# Altium schematic sheet DE-160724.SchDoc(DE-160724)
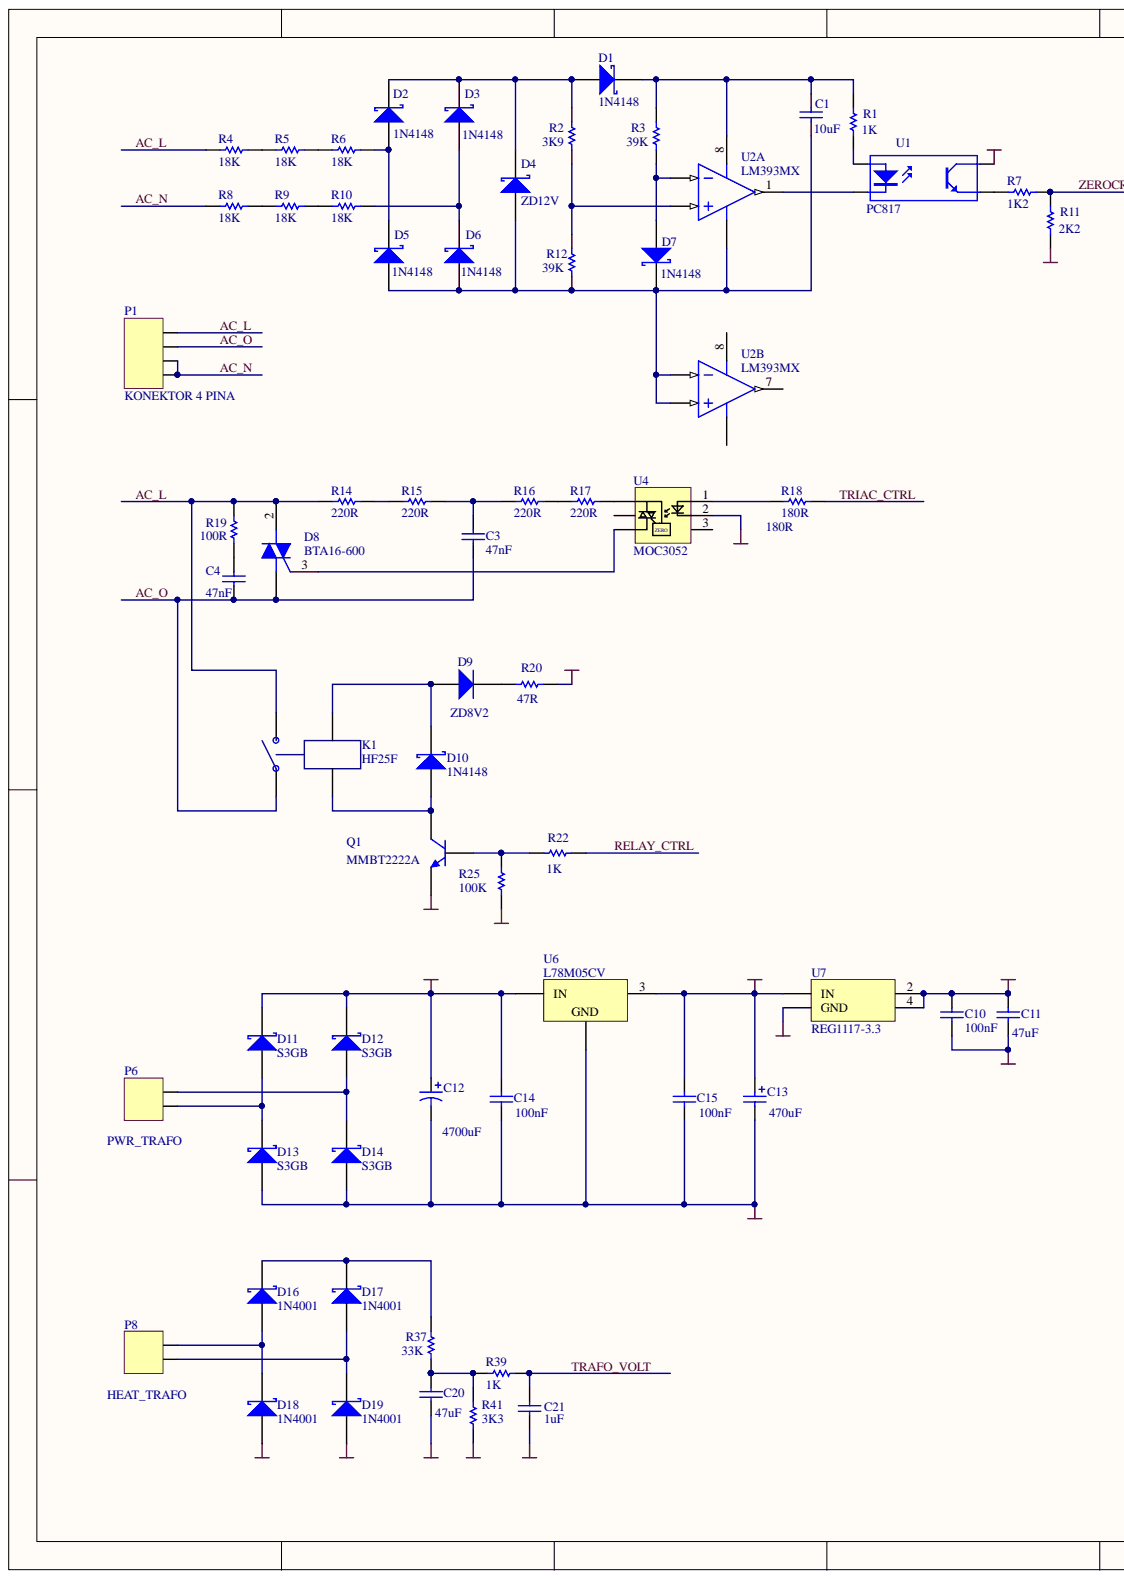
[diagram p0: DE-160724.SchDoc, left]
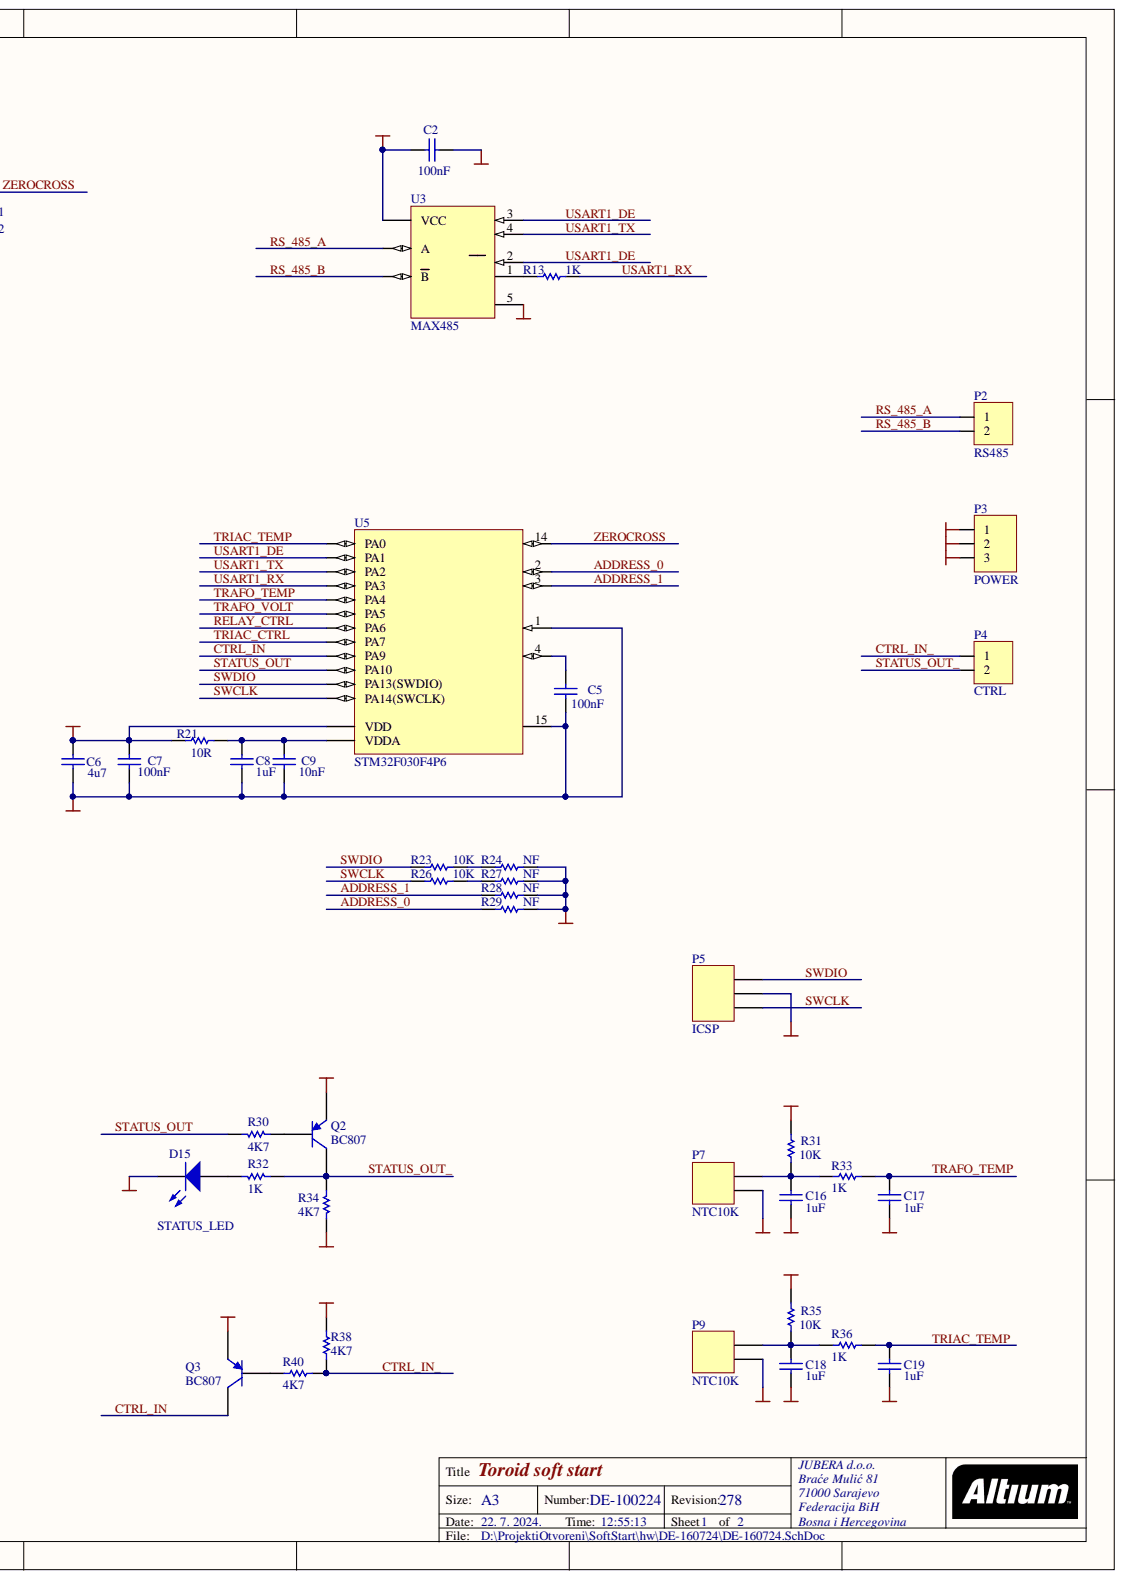
[diagram p1: DE-160724.SchDoc, right]

## Parts
C1  10uF  [0805]
C2  100nF  [0603]
C3  47nF  [X2 CAP]
C4  47nF  [X2 CAP]
C5  100nF  [0603]
C6  4u7  [0805]
C7  100nF  [0603]
C8  1uF  [0603]
C9  10nF  [0603]
C10  100nF  [0603]
C11  value 47uF  [1206]  (hidden comment: C1206)
C12  4700uF  [CAPPR5-13X25]
C13  470uF  [CAPPR5-10X16]
C14  100nF  [0603]
C15  100nF  [0603]
C16  1uF  [0603]
C17  1uF  [0603]
C18  1uF  [0603]
C19  1uF  [0603]
C20  value 47uF  [1206]  (hidden comment: C1206)
C21  1uF  [0603]
D1  1N4148  [SOD323]
D2  1N4148  [SOD323]
D3  1N4148  [SOD323]
D4  ZD12V  [SMB]
D5  1N4148  [SOD323]
D6  1N4148  [SOD323]
D7  1N4148  [SOD323]
D8  BTA16-600  [ONSC-TO-220-3-221A-07]
D9  ZD8V2  [DO-41]
D10  1N4148  [SOD323]
D11  S3GB  [SMB]
D12  S3GB  [SMB]
D13  S3GB  [SMB]
D14  S3GB  [SMB]
D15  STATUS_LED  [1.6X0.8X0.8]
D16  1N4001  [SMB]
D17  1N4001  [SMB]
D18  1N4001  [SMB]
D19  1N4001  [SMB]
K1  HF25F  [HF25F]
P1  KONEKTOR 4 PINA  [KONEKTOR 4 PINA]
P2  RS485  [TERMINAL BLOK 2]
P3  POWER  [TERMINAL BLOK 3]
P4  CTRL  [TERMINAL BLOK 2]
P5  ICSP  [HDR1X3]
P6  PWR_TRAFO  [KONEKTOR 2 PINA]
P7  NTC10K  [HDR1X2]
P8  HEAT_TRAFO  [KONEKTOR 2 PINA]
P9  NTC10K  [HDR1X2]
Q1  MMBT2222A  [SOT23_N]
Q2  BC807  [SOT23_N]
Q3  BC807  [SOT23_N]
R1  1K  [ERA-0603]
R2  3K9  [ERA-0603]
R3  39K  [ERA-0603]
R4  18K  [ERA-0805]
R5  18K  [ERA-0805]
R6  18K  [ERA-0805]
R7  1K2  [ERA-0603]
R8  18K  [ERA-0805]
R9  18K  [ERA-0805]
R10  18K  [ERA-0805]
R11  2K2  [ERA-0603]
R12  39K  [ERA-0603]
R13  1K  [ERA-0603]
R14  220R  [ERA-0805]
R15  220R  [ERA-0805]
R16  220R  [ERA-0805]
R17  220R  [ERA-0805]
R18  180R  [ERA-0603]
R19  100R  [1W100R]
R20  47R  [AXIAL-0.3]
R21  10R  [ERA-0603]
R22  1K  [ERA-0603]
R23  10K  [ERA-0603]
R24  NF  [ERA-0603]
R25  100K  [ERA-0603]
R26  10K  [ERA-0603]
R27  NF  [ERA-0603]
R28  NF  [ERA-0603]
R29  NF  [ERA-0603]
R30  4K7  [ERA-0603]
R31  10K  [ERA-0603]
R32  1K  [ERA-0603]
R33  1K  [ERA-0603]
R34  4K7  [ERA-0603]
R35  10K  [ERA-0603]
R36  1K  [ERA-0603]
R37  33K  [ERA-0603]
R38  4K7  [ERA-0603]
R39  1K  [ERA-0603]
R40  4K7  [ERA-0603]
R41  3K3  [ERA-0603]
U1  PC817  [DIP-4]
U2  LM393MX  [M08A_N]  (parts A,B)
U3  MAX485  [D008_L]
U4  MOC3052  [DIP6]
U5  STM32F030F4P6  [STM-TSSOP20_N]
U6  L78M05CV  [TO220]
U7  REG1117-3.3  [ZZ311]

## Nets
+3V3: C6.1 C7.1 C10.2 C11.2 Q2.2 Q3.2 R21.2 R31.2 R35.2 R38.1 U5.16(VDD) U7.2 U7.4
+5V: C2.1 C13.1 C15.2 P3.1 U1.4 U3.8(VCC) U6.3 U7.3(IN)
+24V: C12.1 C14.2 D11.2 D12.2 P3.3 R20.2 U6.1
GND: C2.2 C5.2 C6.2 C7.2 C8.2 C9.2 C10.1 C11.1 C12.2 C13.2 C14.1 C15.1 C16.2 C17.2 C18.2 C19.2 C20.1 C21.2 D13.1 D14.1 D15.2 D18.1 D19.1 P3.2 P5.2 P7.1 P9.1 Q1.2 R11.1 R24.1 R25.1 R27.1 R28.1 R29.1 R34.2 R41.1 U3.5 U4.2 U5.1 U5.15 U6.2 U7.1(GND)
AC_L: D8.2 K1.4 P1.1 R4.1 R14.1 R19.2
AC_N: P1.3 P1.4 R8.1
AC_O: C3.1 C4.1 D8.1 K1.3 P1.2
ADDRESS_0: R29.2 U5.2
ADDRESS_1: R28.2 U5.3
CTRL_IN: Q3.3 U5.17(PA9)
CTRL_IN_: P4.1 R38.2 R40.2
RELAY_CTRL: R22.1 U5.12(PA6)
RS_485_A: P2.1 U3.6(A)
RS_485_B: P2.2 U3.7(B)
STATUS_OUT: R30.2 U5.18(PA10)
STATUS_OUT_: P4.2 Q2.3 R32.1 R34.1
SWCLK: P5.1 R26.2 U5.20(PA14(SWCLK))
SWDIO: P5.3 R23.2 U5.19(PA13(SWDIO))
TRAFO_TEMP: C17.1 R33.1 U5.10(PA4)
TRAFO_VOLT: C21.1 R39.1 U5.11(PA5)
TRIAC_CTRL: R18.1 U5.13(PA7)
TRIAC_TEMP: C19.1 R36.1 U5.6(PA0)
USART1_DE: U3.2 U3.3 U5.7(PA1)
USART1_RX: R13.1 U5.9(PA3)
USART1_TX: U3.4 U5.8(PA2)
ZEROCROSS: R7.1 R11.2 U5.14
NetC1_1: C1.1 D4.1 D5.2 D6.2 D7.1 R12.1 U2.4 U2.5 U2.6
NetC1_2: C1.2 D1.1 R1.2 R3.2 U2.8
NetC3_2: C3.2 R15.2 R16.1
NetC4_2: C4.2 R19.1
NetC5_1: C5.1 U5.4
NetC8_1: C8.1 C9.1 R21.1 U5.5(VDDA)
NetC16_1: C16.1 P7.2 R31.1 R33.2
NetC18_1: C18.1 P9.2 R35.1 R36.2
NetC20_2: C20.2 R37.1 R39.2 R41.2
NetD1_2: D1.2 D2.1 D3.1 D4.2 R2.2
NetD2_2: D2.2 D5.1 R6.2
NetD3_2: D3.2 D6.1 R10.2
NetD7_2: D7.2 R3.1 U2.2
NetD8_3: D8.3 U4.4
NetD9_1: D9.1 D10.1 K1.2
NetD9_2: D9.2 R20.1
NetD10_2: D10.2 K1.1 Q1.3
NetD11_1: D11.1 D13.2 P6.1
NetD12_1: D12.1 D14.2 P6.2
NetD15_1: D15.1 R32.2
NetD16_1: D16.1 D18.2 P8.2
NetD16_2: D16.2 D17.2 R37.2
NetD17_1: D17.1 D19.2 P8.1
NetQ1_1: Q1.1 R22.2 R25.2
NetQ2_1: Q2.1 R30.1
NetQ3_1: Q3.1 R40.1
NetR1_1: R1.1 U1.1
NetR2_1: R2.1 R12.2 U2.3
NetR4_2: R4.2 R5.1
NetR5_2: R5.2 R6.1
NetR7_2: R7.2 U1.3
NetR8_2: R8.2 R9.1
NetR9_2: R9.2 R10.1
NetR13_2: R13.2 U3.1
NetR14_2: R14.2 R15.1
NetR16_2: R16.2 R17.1
NetR17_2: R17.2 U4.6
NetR18_2: R18.2 U4.1
NetR23_1: R23.1 R24.2
NetR26_1: R26.1 R27.2
NetU1_2: U1.2 U2.1

Not connected: U2 7; U4 3 5

## Library data of the parts
C1 C6 = C0805: Ceramic Chip Capacitor - Standard; Note: Footprint corresponds to dimensions for bulk cassette packaging. This case size has tightened tolerances.; Simulation: CAP; Signal Integrity: C0805; Published: 17-Jul-2002; PackageReference: 0805; PackageDocument: Mar-2001; LatestRevisionNote: Silkscreen size reduced.; LatestRevisionDate: 19-Mar-2004; DatasheetDocument: Mar-2001; ComponentLink2URL: http://www.kemet.com/kemet/web/homepage/kechome.nsf/vapubfilesname/F3102TA.pdf/$file/F3102TA.pdf; ComponentLink2Description: Datasheet; ComponentLink1URL: http://www.kemet.com/; ComponentLink1Description: Manufacturer Link; Component Kind: Standard; Code_JEITA: 0805; Code_IPC: 2012[0805]; Publisher: Altium Limited
  C1: Value: 10uF; Library Name: DE-100224.SchLib
  C6: Value: 4u7; Library Name: KEMET Chip Capacitor.IntLib
C2 C5 C7-C10 C14-C19 C21 = C0603: Ceramic Chip Capacitor - Standard; Note: Footprint corresponds to dimensions for bulk cassette packaging. This case size has tightened tolerances.; Simulation: CAP; Signal Integrity: C0603; Published: 17-Jul-2002; PackageReference: 0603; PackageDocument: Mar-2001; LatestRevisionNote: Silkscreen size reduced.; LatestRevisionDate: 19-Mar-2004; DatasheetDocument: Mar-2001; ComponentLink2URL: http://www.kemet.com/kemet/web/homepage/kechome.nsf/vapubfilesname/F3102TA.pdf/$file/F3102TA.pdf; ComponentLink2Description: Datasheet; ComponentLink1URL: http://www.kemet.com/; ComponentLink1Description: Manufacturer Link; Component Kind: Standard; Code_JEITA: 0603; Code_IPC: 1608 [0603]; Publisher: Altium Limited
  C2 C5 C7: Value: 100nF; Library Name: KEMET Chip Capacitor.IntLib
  C8 C16-C19 C21: Value: 1uF; Library Name: KEMET Chip Capacitor.IntLib
  C9: Value: 10nF; Library Name: KEMET Chip Capacitor.IntLib
  C10: Library Name: DE-251215.SchLib
  C14 C15: Value: 100nF; Library Name: DE-100224.SchLib
C3 C4 = CAP X2: X2 275VAC capaitor; Library Name: DE-100224.SchLib; Component Kind: Standard
C11 C20 = C1206: Ceramic Chip Capacitor - Standard; Simulation: CAP; Signal Integrity: C1206; Published: 17-Jul-2002; PackageReference: 1206; PackageDocument: Mar-2001; Library Name: DE-160724.SchLib; LatestRevisionNote: Silkscreen size reduced.; LatestRevisionDate: 19-Mar-2004; DatasheetDocument: Mar-2001; ComponentLink2URL: http://www.kemet.com/kemet/web/homepage/kechome.nsf/vapubfilesname/F3102TA.pdf/$file/F3102TA.pdf; ComponentLink2Description: Datasheet; ComponentLink1URL: http://www.kemet.com/; ComponentLink1Description: Manufacturer Link; Component Kind: Standard; Code_JEITA: 1206; Code_IPC: 3216 [1206]; Publisher: Altium Limited
C12 = EKA00GG447C00: Aluminum Electrolytic Capacitor, Radial Style with Long Leads: 4700uF, 10V; Note: Refer to Manufacturer's Data Sheets for other lead formations; DrillSize: Nominal, Rounded To The Nearest 0.1mm; Value: 4700uF; Simulation: CAP; Signal Integrity: EKA00GG447C00; Published: 19-Mar-2004; PackageReference: CAPPR5-13X25; DatasheetDocument: 20-Feb-2001; ComponentLink2URL: http://www.vishay.com/docs/25014/eka.pdf; ComponentLink2Description: Datasheet; ComponentLink1URL: http://www.vishay.com/; ComponentLink1Description: Manufacturer Link; Component Kind: Standard; Publisher: Altium Limited; Library Name: Vishay Roederstein Electrolytic Radial-Lead Capacitor.IntLib
C13 = EKA00DD347F00: Aluminum Electrolytic Capacitor, Radial Style with Long Leads: 470uF, 35V; Note: Refer to Manufacturer's Data Sheets for other lead formations; DrillSize: Nominal, Rounded To The Nearest 0.1mm; Value: 470uF; Simulation: CAP; Signal Integrity: EKA00DD347F00; Published: 19-Mar-2004; PackageReference: CAPPR5-10X16; Library Name: DE-100224.SchLib; DatasheetDocument: 20-Feb-2001; ComponentLink2URL: http://www.vishay.com/docs/25014/eka.pdf; ComponentLink2Description: Datasheet; ComponentLink1URL: http://www.vishay.com/; ComponentLink1Description: Manufacturer Link; Component Kind: Standard; Publisher: Altium Limited
D1-D3 D5-D7 D10 = 1PS76SB10: Schottky Barrier Diode; Simulation: D1PS76SBD10; Signal Integrity: 1PS76SB10; Published: 17-Sep-2003; PackageVersion: May-1999; PackageReference: SOD323; PackageDescription: SOD-323/SC-76; 2 Leads; Body 1.7 x 1.3 mm, (LxW); Library Name: DE-100224.SchLib; LatestRevisionNote: Silkscreen size reduced.; LatestRevisionDate: 19-Mar-2004; DatasheetVersion: 14-Oct-1996; ComponentLink2URL: http://www.semiconductors.philips.com/acrobat/datasheets/1PS76SB10_2.pdf; ComponentLink2Description: Datasheet; ComponentLink1URL: http://www.semiconductors.philips.com/; ComponentLink1Description: Manufacturer Link; Component Kind: Standard; Publisher: Altium Limited
D4 = 10BQ100: Schottky Rectifier; Simulation: 10BQ100; Signal Integrity: 10BQ100; Published: 8-Jun-2000; PackageReference: SMB; PackageDescription: DO-214-AA/SMB; 2 C-Bend Leads; Body 5.3 x 3.6 mm, inc. leads (LxW); Library Name: DE-100224.SchLib; LatestRevisionNote: Re-released for DXP Platform.; LatestRevisionDate: 17-Jul-2002; DatasheetVersion: PD-2.437A; ComponentLink2URL: http://www.irf.com/product-info/datasheets/data/10bq100.pdf; ComponentLink2Description: Datasheet; ComponentLink1URL: http://www.irf.com/; ComponentLink1Description: Manufacturer Link; Component Kind: Standard; Publisher: Altium Limited
D8 = BTA08-600BW3G: Triacs, Silicon Bidirectional Thyristor, 3-Pin TO-220, Pb-Free, Tube; Manufacturer: On Semiconductor; ITSM Max (A): 90; IT(RMS) Max (A): 8; IGT Max (mA): 50; Supplier Part Number 3: 863-BTA08-600BW3G; Supplier Part Number 2: 13P2072; Supplier Part Number 1: BTA08-600BW3G-ND; Supplier 3: Mouser; Supplier 2: Newark; Supplier 1: Digi-Key; RoHS: Pb-Free; PartNumber: BTA08-600BW3G; Packing: Tube; PackageVersion: Rev. O, 07/2008; PackageReference: TO-220-3-221A-07; PackageDescription: 3-Pin Flange Mount, Vertical Straight Lead, Body 9.97 x 4.445 mm, Pitch 2.54 mm; Mounting Technology: Through Hole; Library Name: DE-100224.SchLib; DatasheetVersion: Rev. 1; ComponentLink3URL: http://www.onsemi.com/pub_link/Collateral/221A-07.PDF; ComponentLink3Description: Package Specification; ComponentLink2URL: http://www.onsemi.com/pub_link/Collateral/BTA08-600BW3-D.PDF; ComponentLink2Description: Datasheet; ComponentLink1URL: http://www.onsemi.com/; ComponentLink1Description: Manufacturer URL; Component Kind: Standard
D9 = 1N4003: 1.0 Ampere General Purpose Rectifier; Simulation: 1N4003; Signal Integrity: 1N4003; Published: 8-Jun-2000; PackageReference: DO-41; PackageDocument: Aug-1999; Library Name: DE-100224.SchLib; LatestRevisionNote: Re-released for DXP Platform.; LatestRevisionDate: 17-Jul-2002; DatasheetDocument: 1998; ComponentLink2URL: http://www.fairchildsemi.com/ds/1N%2F1N4001.pdf; ComponentLink2Description: Pinout Specification; ComponentLink1URL: http://www.fairchildsemi.com/; ComponentLink1Description: Manufacturer Link; Component Kind: Standard; Code_JEDEC: DO-204-AL; Publisher: Altium Limited
D11-D14 D16-D19 = D Schottky: Schottky Diode; Simulation: SKYDIODE; Signal Integrity: D Schottky; Published: 8-Jun-2000; PackageReference: SMB; PackageDescription: DO-214-AA/SMB; 2 C-Bend Leads; Body 5.3 x 3.6 mm, inc. leads (LxW); Library Name: Miscellaneous Devices.IntLib; LatestRevisionNote: Re-released for DXP Platform.; LatestRevisionDate: 17-Jul-2002; Component Kind: Standard; Code_JEDEC: DO-214-AA; Publisher: Altium Limited
D15 = HSMB-C190: Blue Surface Mount Chip LED, Blue; PCB_Layout: Complies with manufacturer's recommendation.; LuminousIntensity: 1.6mcd (Minimum).; Simulation: Agl_HSMBC110; Signal Integrity: LED; Published: 11-Dec-2002; PackageVersion: Mar-2002; PackageReference: 1.6X0.8X0.8; PackageDescription: SM LED; 2 Flat Leads; Library Name: DE-160724.SchLib; LED_Colour: Blue; DatasheetVersion: 26-Feb-2002; ComponentLink2URL: http://cp.literature.agilent.com/litweb/pdf/5988-5211EN.pdf; ComponentLink2Description: Datasheet; ComponentLink1URL: http://www.avagotech.com/; ComponentLink1Description: Manufacturer Link; Component Kind: Standard; Publisher: Altium Limited
K1 = HF25F: PCB relay 250VAC/20A 30VDC/16A; Library Name: DE-100224.SchLib; Component Kind: Standard
P1 = KONEKTOR 4 PINA: Razdvojivi konektor 4 pina; Library Name: DE-100224.SchLib; Component Kind: Standard
P2 P4 = TERMINAL BLOK 2: Terminal blok konektor 3,5 mm; Library Name: DE-160724.SchLib; Component Kind: Standard
P3 = TERMINAL BLOK 3: 3 pinski terminal blok konektor; Library Name: DE-100224.SchLib; Component Kind: Standard
P5 = Header 3: Header, 3-Pin; Signal Integrity: Connector; Library Name: Miscellaneous Connectors.IntLib; LatestRevisionNote: Re-released for DXP Platform.; LatestRevisionDate: 17-Jul-2002; Component Kind: Standard; Publisher: Altium Limited
P6 P8 = KONEKTOR 2 PINA: Razdvojivi konetor 2 pina; Library Name: DE-100224.SchLib; Component Kind: Standard
P7 P9 = Header 2: Header, 2-Pin; Signal Integrity: Connector; Library Name: Miscellaneous Connectors.IntLib; LatestRevisionNote: Re-released for DXP Platform.; LatestRevisionDate: 17-Jul-2002; Component Kind: Standard; Publisher: Altium Limited
Q1 = BC817: NPN General-purpose Transistor; Simulation: QBC817; Signal Integrity: BC817; Published: 17-Sep-2003; PackageVersion: May-1999; PackageReference: SOT23; PackageDescription: 3-Pin SOT-23 Package 0.95 mm Pitch, 2.3 mm Lead Span; Library Name: DE-100224.SchLib; LatestRevisionNote: IPC-7351 Footprint Added.; LatestRevisionDate: 12-Dec-2006; DatasheetVersion: Jun-1999; ComponentLink2URL: http://www.semiconductors.philips.com/acrobat/datasheets/BC817_BC817W_BC337_5.pdf; ComponentLink2Description: Datasheet; ComponentLink1URL: http://www.semiconductors.philips.com/; ComponentLink1Description: Manufacturer Link; Component Kind: Standard; Publisher: Altium Limited
Q2 Q3 = BC807: PNP General-purpose Transistor; Simulation: QBC807; Signal Integrity: BC807; Published: 17-Sep-2003; PackageVersion: May-1999; PackageReference: SOT23; PackageDescription: 3-Pin SOT-23 Package 0.95 mm Pitch, 2.3 mm Lead Span; Library Name: DE-160724.SchLib; LatestRevisionNote: IPC-7351 Footprint Added.; LatestRevisionDate: 12-Dec-2006; DatasheetVersion: 8-Apr-1999; ComponentLink2URL: http://www.semiconductors.philips.com/acrobat/datasheets/BC807_BC807W_BC327_5.pdf; ComponentLink2Description: Datasheet; ComponentLink1URL: http://www.semiconductors.philips.com/; ComponentLink1Description: Manufacturer Link; Component Kind: Standard; Publisher: Altium Limited
R1-R3 R7 R11 R12 R18 R22 R25 R31 R33 R35-R37 R39 R41 = ERAV: Metal Film Thermosensitive Chip Resistor, 0.56 Ohm to 10k Ohm Range, 5% Tolerance, 0603 Size, 0.063 W; Note: If flow soldering, adjust pad width of PCB Footprint to 0.7 - 0.8 times the width of the resistor.; Simulation: RESISTOR; Signal Integrity: Res; Published: 17-Dec-2003; PackageReference: ERA-0603; PackageDocument: Mar-2003; Library Name: DE-100224.SchLib; LatestRevisionNote: Silkscreen size reduced.; LatestRevisionDate: 19-Mar-2004; ComponentLink2URL: http://www.panasonic.com/industrial/components/pdf/AOF0000CE1.pdf; ComponentLink2Description: Datasheet; ComponentLink1URL: http://www.panasonic.com/industrial/components/resistive_products.htm; ComponentLink1Description: Manufacturer Link; Component Kind: Standard; Code_IPC: 1608 [0603]; Publisher: Altium Limited
  R18: Value: 180R
R4-R6 R8-R10 R14-R17 = ERAS: Metal Film Thermosensitive Chip Resistor, 0.56 Ohm to 10k Ohm Range, 5% Tolerance, 0805 Size, 0.1 W; Note: If flow soldering, adjust pad width of PCB Footprint to 0.7 - 0.8 times the width of the resistor.; Simulation: RESISTOR; Signal Integrity: Res; Published: 17-Dec-2003; PackageReference: ERA-0805; PackageDocument: Mar-2003; Library Name: DE-100224.SchLib; LatestRevisionNote: Silkscreen size reduced.; LatestRevisionDate: 19-Mar-2004; ComponentLink2URL: http://www.panasonic.com/industrial/components/pdf/AOF0000CE1.pdf; ComponentLink2Description: Datasheet; ComponentLink1URL: http://www.panasonic.com/industrial/components/resistive_products.htm; ComponentLink1Description: Manufacturer Link; Component Kind: Standard; Code_IPC: 2012 [0805]; Publisher: Altium Limited
R13 R21 R23 R24 R26-R30 R32 R34 R38 R40 = ERA6Y: Rectangular Thick Film Chip Resistor, 10 Ohm to 1M Ohm Range, 0.1% and 0.5% Tolerance, 0805 Size, 0.1 W; Note: If flow soldering, adjust pad width of PCB Footprint to 0.7 - 0.8 times the width of the resistor.; Simulation: RESISTOR; Signal Integrity: Res; Published: 17-Dec-2003; PackageReference: ERA-0805; PackageDocument: Mar-2003; Library Name: DE-280817.SchLib; LatestRevisionNote: Silkscreen size reduced.; LatestRevisionDate: 19-Mar-2004; ComponentLink2URL: http://www.panasonic.com/industrial/components/pdf/AOA0000CE8.pdf; ComponentLink2Description: Datasheet; ComponentLink1URL: http://www.panasonic.com/industrial/components/resistive_products.htm; ComponentLink1Description: Manufacturer Link; Component Kind: Standard; Code_IPC: 2012 [0805]; Publisher: Altium Limited
R19 = 1W100R: Carbon F 1W resistor; Published: 17-Dec-2003; PackageReference: RD6.35x2.3; Library Name: DE-100224.SchLib; ComponentLink2URL: http://www.panasonic.com/industrial/components/pdf/AOA0000CE12.pdf; ComponentLink2Description: Datasheet; ComponentLink1URL: http://www.panasonic.com/industrial/components/resistive_products.htm; ComponentLink1Description: Manufacturer Link; Component Kind: Standard; Publisher: Altium Limited
R20 = Res1: Resistor; Value: 47R; Simulation: RESISTOR; Signal Integrity: Res; Published: 8-Jun-2000; PackageReference: AXIAL-0.3; PackageDescription: Axial Device, Thru-Hole; 2 Leads; 0.3 in Pin Spacing; Library Name: Miscellaneous Devices.IntLib; LatestRevisionNote: Re-released for DXP Platform.; LatestRevisionDate: 17-Jul-2002; Component Kind: Standard; Publisher: Altium Limited
U1 = PC817: 4-Pin Phototransistor Optocoupler; Published: 24-Mar-1999; PackageReference: DIP-4; Library Name: DE-100224.SchLib; LatestRevisionNote: Sch pins 3 & 4 Swapped.; LatestRevisionDate: 04-Mar-2005; DatasheetVersion: Nov-2003; Component Kind: Standard; Publisher: Altium Limited
U2 = LM393MX: Low Power Low Offset Voltage Dual Comparator, 8-pin Narrow SOIC; Manufacturer: National Semiconductor; PowerWise: N; PackageTopMarking: NSZXTT*LM*393M; High-Reliability: Y; RoHS: N; PinCount: 8; PartNumber: LM393MX; PackageVersion: revM, May-2009; PackageReference: M08A; PackageDescription: SOIC Narrow, 4.9 x 3.9 x 1.45mm, 8 Lead, 1.27mm Pitch; Library Name: National Semiconductor Comparator.IntLib; DatasheetVersion: Aug-2002; CompType: Comparator; ComponentLink4URL: http://www.national.com/packaging/folders/m08a.html; ComponentLink4Description: M08A Package Page; ComponentLink3URL: http://www.national.com/pf/LM/LM393.html; ComponentLink3Description: LM393 Web Page; ComponentLink2URL: http://www.national.com/ds/LM/LM393.pdf; ComponentLink2Description: LM393 Datasheet; ComponentLink1URL: http://www.national.com/; ComponentLink1Description: Manufacturer URL; Component Kind: Standard; Code_JEDEC: MS-012-AA; Code_IPC: SOIC127P600-8
U3 = SN75176BD: Differential Bus Transceiver; Note: Receiver Hysteresis of 50mV Typical; Published: 15-May-2002; PCB3D: D008; PackageVersion: Jan-1998; PackageReference: D008; PackageDescription: 8-Pin Small Outline Integrated Circuit 1.27 mm Pitch; Library Name: DE-280817.SchLib; LatestRevisionNote: IPC-7351 Footprint Added.; LatestRevisionDate: 13-Apr-2006; DatasheetVersion: Sep-1989; ComponentLink2URL: http://www-s.ti.com/sc/ds/sn75176b.pdf; ComponentLink2Description: Datasheet; ComponentLink1URL: http://www.ti.com/; ComponentLink1Description: Manufacturer Link; Component Kind: Standard; Code_IPC: SOIC127P600-8; Publisher: Altium Limited
U4 = MOC3081: Zero-Cross Optoisolator Triac Driver; Library Name: DE-100224.SchLib; Component Kind: Standard
U5 = STM32F030F4P6: ARM Cortex-M0 32-bit MCU, 16 KB Flash, 4 KB Internal RAM, 15 I/Os, 20-pin TSSOP, -40 to 85 degC, Tube; Supply Voltage(Vcc) min (V): 2.4; Operating Frequency(F) (Processor speed) (MHz): 48; Internal RAM Size (kB): 4; I/Os (High Current): 15; FLASH Size (Prog) (kB): 16; Supplier Part Number 3: 511-STM32F030F4P6; Supplier Part Number 2: 06X3648; Supplier Part Number 1: 497-14044-5-ND; Supplier 3: Mouser; Supplier 2: Newark; Supplier 1: Digi-Key; PartNumber: STM32F030F4P6; Packing: Tube; PackageVersion: Rev. 1, 11/2012; PackageReference: TSSOP20; PackageDescription: 20-Pin Thin Shrink Small Outline Package, 6.5 x 4.4 mm Body, 0.65 mm Pitch; Mounting Technology: Surface Mount; Library Name: STMicroelectronics STM32 F0.IntLib; DatasheetVersion: Rev. 1; Core: ARM Cortex-M0; ComponentLink3URL: http://www.st.com/st-web-ui/static/active/en/resource/technical/document/datasheet/DM00065136.pdf; ComponentLink3Description: Package Specification; ComponentLink2URL: http://www.st.com/st-web-ui/static/active/en/resource/technical/document/datasheet/DM00088500.pdf; ComponentLink2Description: Datasheet; ComponentLink1URL: http://www.st.com/web/en/home.html; ComponentLink1Description: Manufacturer URL; Component Kind: Standard
U6 = L78M05CV: Positive Voltage Regulator, 5V, 3-Pin TO-220; PartNumber: L78M05CV; PackageVersion: ver3.0; PackageReference: TO220; PackageDescription: TO, Thru-Hole, Vertical, Heatsink Mounted; 3 In-Line Leads; Pitch 2.54 mm; Library Name: STMicroelectronics Power Management Linear Regulator.IntLib; DatasheetVersion: Nov-2010; ComponentLink3URL: http://www.st.com/internet/com/TECHNICAL_RESOURCES/TECHNICAL_LITERATURE/PACKAGE_INFORMATION/CD00004649.pdf; ComponentLink3Description: Package Specification; ComponentLink2URL: http://www.st.com/internet/com/TECHNICAL_RESOURCES/TECHNICAL_LITERATURE/DATASHEET/CD00000447.pdf; ComponentLink2Description: Datasheet; ComponentLink1URL: http://www.st.com; ComponentLink1Description: Manufacturer URL; Component Kind: Standard
U7 = REG1117-3.3: 800mA and 1A Low Dropout Positive Regulator 2.85V, 3.3V, 5V, and Adjustable; Published: 8-Jun-2000; PackageVersion: revC; PackageReference: ZZ311; PackageDescription: SOT-223/SC-73; 4 Leads; Body 6.7 x 7.3 mm, inc. leads (LxW max); Library Name: DE-251215.SchLib; LatestRevisionNote: Re-released for DXP Platform.; LatestRevisionDate: 17-Jul-2002; DatasheetVersion: Sep-1997; ComponentLink2URL: http://www-s.ti.com/sc/ds/REG1117.pdf; ComponentLink2Description: Datasheet; ComponentLink1URL: http://www.ti.com/; ComponentLink1Description: Manufacturer Link; Component Kind: Standard; Publisher: Altium Limited
